# Altium schematic sheet 仪表.SchDoc
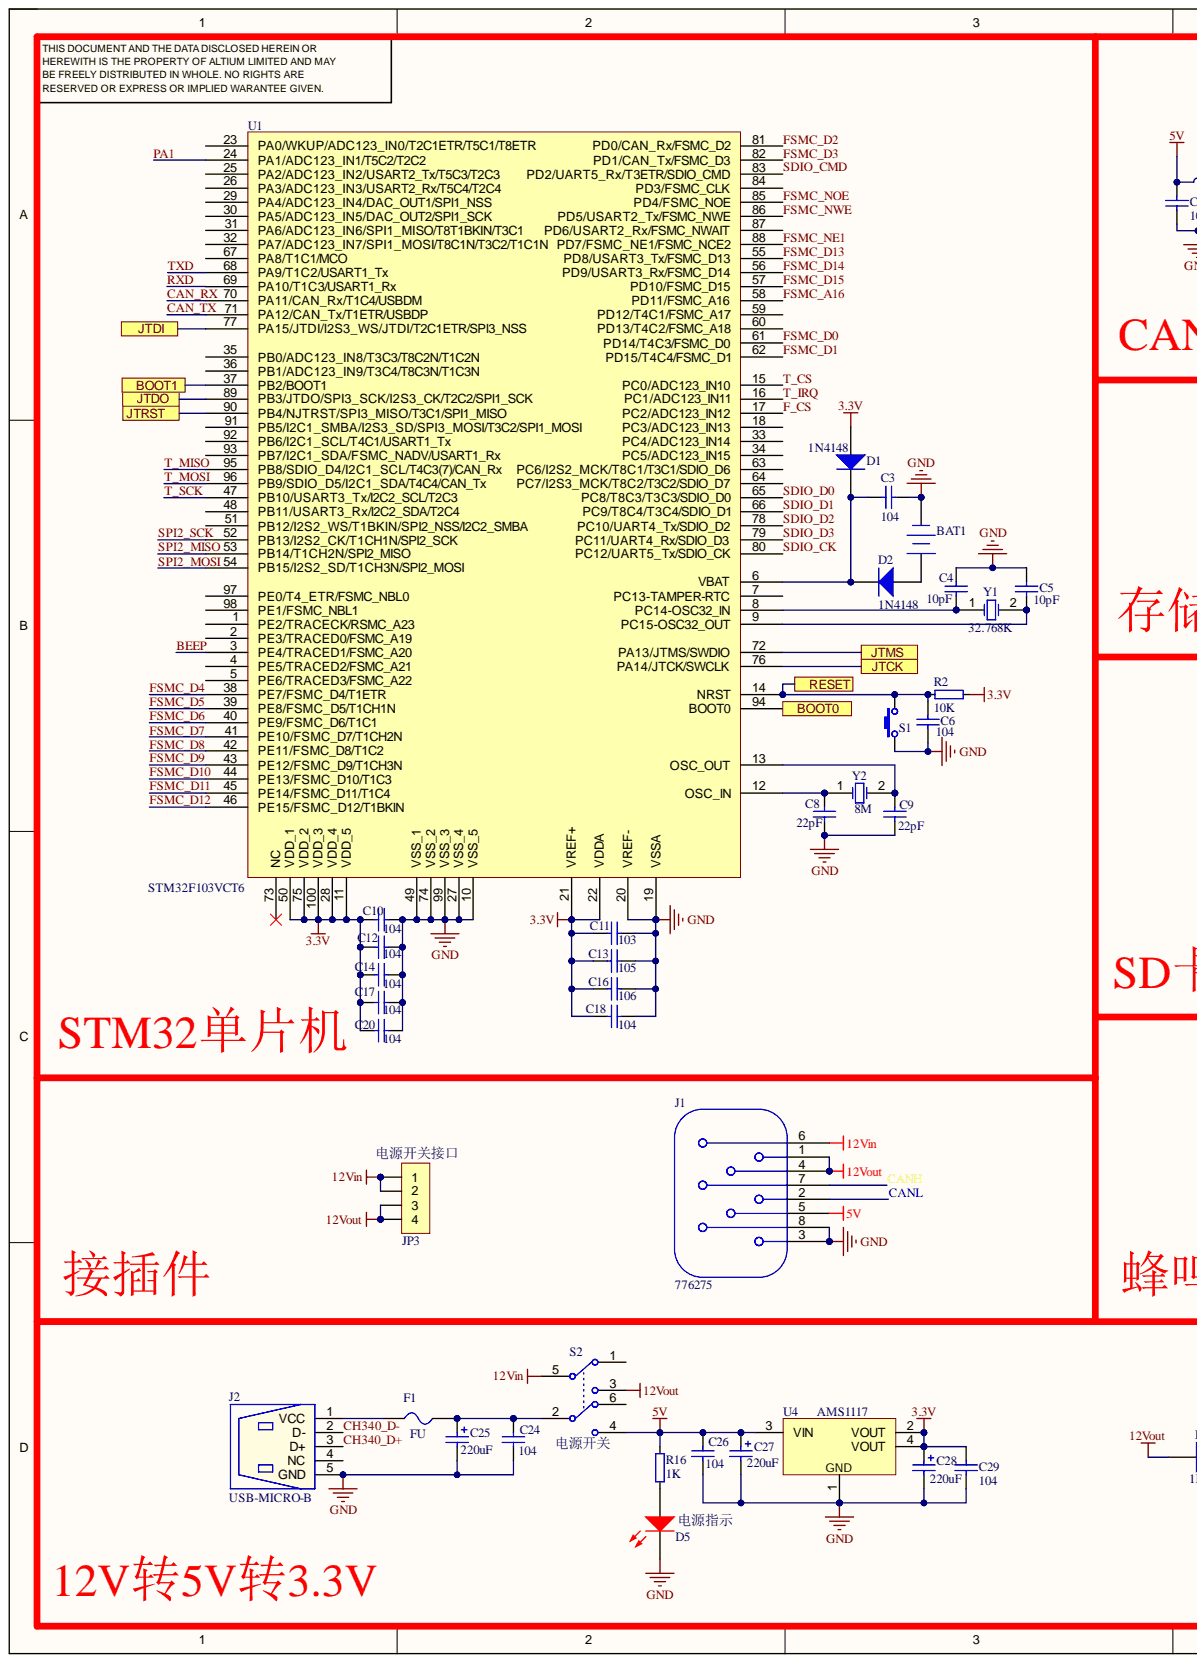
[diagram p0: 仪表.SchDoc, left]
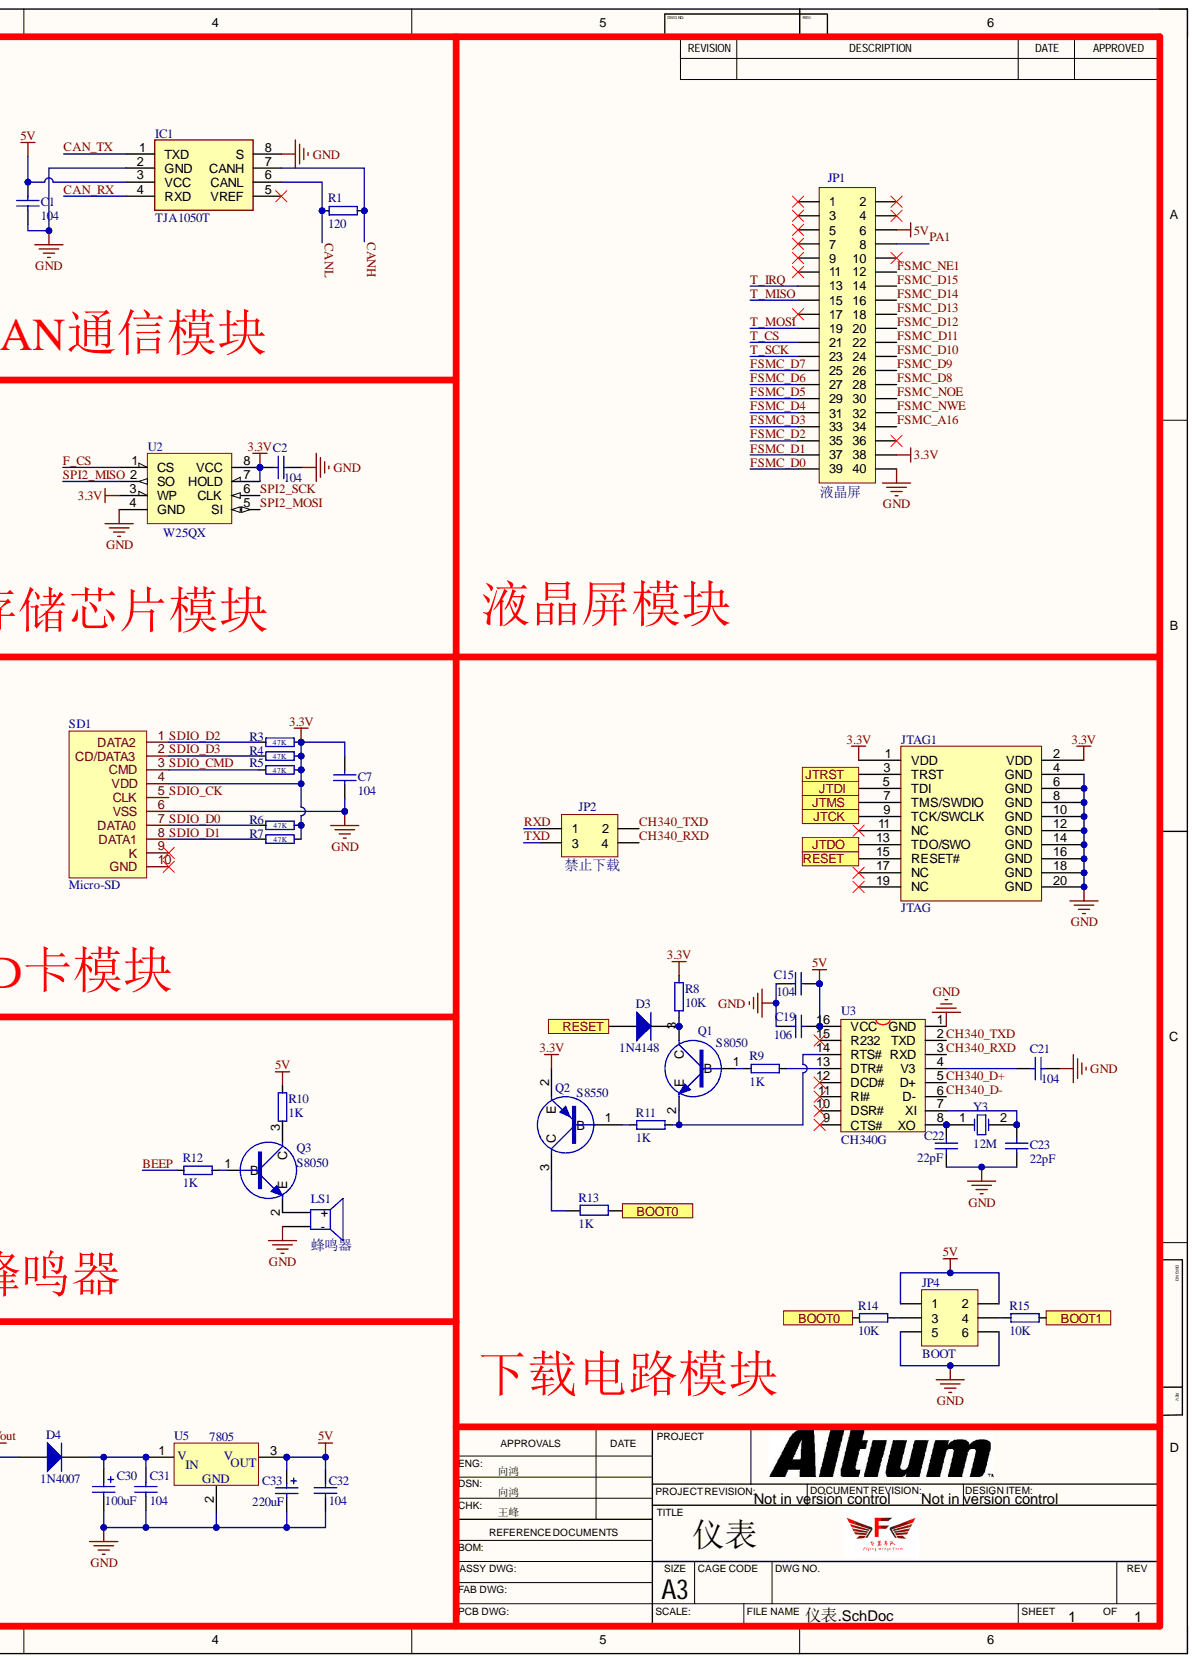
[diagram p1: 仪表.SchDoc, right]

## Parts
BAT1  Battery  [CR1220_B]  (value not drawn)
C1  104  [C0805]
C2  104  [C0805]
C3  104  [C0805]
C4  10pF  [C0805]
C5  10pF  [C0805]
C6  104  [C0805]
C7  104  [C0805]
C8  22pF  [C0805]
C9  22pF  [C0805]
C10  104  [C0805]
C11  103  [C0805]
C12  104  [C0805]
C13  105  [C0805]
C14  104  [C0805]
C15  104  [C0805]
C16  106  [C0805]
C17  104  [C0805]
C18  104  [C0805]
C19  106  [C0805]
C20  104  [C0805]
C21  104  [C0805]
C22  22pF  [C0805]
C23  22pF  [C0805]
C24  104  [C0805]
C25  220uF  [C5X8]
C26  104  [C0805]
C27  220uF  [C5X8]
C28  220uF  [C5X8]
C29  104  [C0805]
C30  100uF  [C5X8]
C31  104  [C0805]
C32  104  [C0805]
C33  220uF  [C5X8]
D1  1N4148  [DO-35]
D2  1N4148  [DO-35]
D3  1N4148  [DO-35]
D4  1N4007  [DO-41]
D5  电源指示  [LED-0805R]
F1  FU  [FU 0805]
IC1  TJA1050T  [TJA1050T]
J1  776275  [776275]
J2  USB-MICRO-B  [USB-Micro-SMT-F4-AB]
JP1  液晶屏  [HDR2.54-M-LS-2x20P]
JP2  禁止下载  [HDR2.54-LI-2x2P]
JP3  电源开关接口  [XH2.54-WI-4P]
JP4  BOOT  [HDR2.54-LI-2x3P]
JTAG1  JTAG  [HDR2.54-LI-2x10P]
LS1  蜂鸣器  [BEEP 7.6x12x9.5]
Q1  S8050  [SOT23-3M]
Q2  S8550  [SOT23-3M]
Q3  S8050  [SOT23-3M]
R1  120  [R0805]
R2  10K  [R0805]
R3  47K  [R0805]
R4  47K  [R0805]
R5  47K  [R0805]
R6  47K  [R0805]
R7  47K  [R0805]
R8  10K  [R0805]
R9  1K  [R0805]
R10  1K  [R0805]
R11  1K  [R0805]
R12  1K  [R0805]
R13  1K  [R0805]
R14  10K  [R0805]
R15  10K  [R0805]
R16  1K  [R0805]
S1  RESET  [SW-6*6*4.3]
S2  电源开关  [KFT DIP-8X8]
SD1  Micro-SD  [Micro SD]
U1  STM32F103VCT6  [LQFP-100_M]
U2  W25QX  [SOP8]
U3  CH340G  [SOP16_M]
U4  AMS1117  [SOT-223]
U5  7805  [TO220]
Y1  32.768K  [OSC-2x6-WI-A]
Y2  8M  [OSC HC-49S]
Y3  12M  [OSC HC-49S]

## Ports
BOOT0, BOOT1, JTCK, JTDI, JTDO, JTMS, JTRST, RESET

## Nets
3.3V: C2.1 C7.2 C10.1 C11.1 C12.1 C13.1 C14.1 C16.1 C17.1 C18.1 C20.1 C28.1 C29.2 D1.1 JP1.38 JTAG1.1(VDD) JTAG1.2(VDD) Q2.2 R2.2 R3.2 R4.2 R5.2 R6.2 R7.2 R8.2 SD1.4(VDD) U1.11(VDD_5) U1.21(VREF+) U1.22(VDDA) U1.28(VDD_4) U1.50(VDD_1) U1.75(VDD_2) U1.100(VDD_3) U2.3(WP) U2.7(HOLD) U2.8(VCC) U4.2(VOUT) U4.4(VOUT)
5V: C1.2 C15.1 C19.1 C26.2 C27.1 C32.2 C33.1 IC1.3(VCC) J1.5 JP1.6 JP4.1 JP4.2 R10.2 R16.2 S2.4 U3.16(VCC) U4.3(VIN) U5.3
12Vin: J1.6 JP3.1 JP3.2 S2.5
12Vout: D4.1 J1.1 J1.4 JP3.3 JP3.4 S2.3
GND: BAT1.2 C1.1 C2.2 C3.1 C4.2 C5.2 C6.1 C7.1 C8.1 C9.1 C10.2 C11.2 C12.2 C13.2 C14.2 C15.2 C16.2 C17.2 C18.2 C19.2 C20.2 C21.1 C22.1 C23.1 C24.1 C25.2 C26.1 C27.2 C28.2 C29.1 C30.2 C31.1 C32.1 C33.2 D5.2 IC1.2(GND) IC1.8(S) J1.3 J1.8 J2.5(GND) JP1.40 JP4.5 JP4.6 JTAG1.4(GND) JTAG1.6(GND) JTAG1.8(GND) JTAG1.10(GND) JTAG1.12(GND) JTAG1.14(GND) JTAG1.16(GND) JTAG1.18(GND) JTAG1.20(GND) LS1.2 S1.1 SD1.6(VSS) U1.10(VSS_5) U1.19(VSSA) U1.20(VREF-) U1.27(VSS_4) U1.49(VSS_1) U1.74(VSS_2) U1.99(VSS_3) U2.4(GND) U3.1(GND) U4.1 U5.2
BEEP: R12.1 U1.3(PE4/TRACED1/FSMC_A20)
BOOT0: R13.1 R14.1 U1.94(BOOT0) ; port BOOT0
BOOT1: R15.2 U1.37(PB2/BOOT1) ; port BOOT1
CAN_RX: IC1.4(RXD) U1.70(PA11/CAN_Rx/T1C4/USBDM)
CAN_TX: IC1.1(TXD) U1.71(PA12/CAN_Tx/T1ETR/USBDP)
CANH: IC1.7(CANH) J1.7 R1.2
CANL: IC1.6(CANL) J1.2 R1.1
CH340_D+: J2.3(D+) U3.5(D+)
CH340_D-: J2.2(D-) U3.6(D-)
CH340_RXD: JP2.4 U3.3(RXD)
CH340_TXD: JP2.2 U3.2(TXD)
F_CS: U1.17(PC2/ADC123_IN12) U2.1(CS)
FSMC_A16: JP1.34 U1.58(PD11/FSMC_A16)
FSMC_D0: JP1.39 U1.61(PD14/T4C3/FSMC_D0)
FSMC_D1: JP1.37 U1.62(PD15/T4C4/FSMC_D1)
FSMC_D2: JP1.35 U1.81(PD0/CAN_Rx/FSMC_D2)
FSMC_D3: JP1.33 U1.82(PD1/CAN_Tx/FSMC_D3)
FSMC_D4: JP1.31 U1.38(PE7/FSMC_D4/T1ETR)
FSMC_D5: JP1.29 U1.39(PE8/FSMC_D5/T1CH1N)
FSMC_D6: JP1.27 U1.40(PE9/FSMC_D6/T1C1)
FSMC_D7: JP1.25 U1.41(PE10/FSMC_D7/T1CH2N)
FSMC_D8: JP1.28 U1.42(PE11/FSMC_D8/T1C2)
FSMC_D9: JP1.26 U1.43(PE12/FSMC_D9/T1CH3N)
FSMC_D10: JP1.24 U1.44(PE13/FSMC_D10/T1C3)
FSMC_D11: JP1.22 U1.45(PE14/FSMC_D11/T1C4)
FSMC_D12: JP1.20 U1.46(PE15/FSMC_D12/T1BKIN)
FSMC_D13: JP1.18 U1.55(PD8/USART3_Tx/FSMC_D13)
FSMC_D14: JP1.16 U1.56(PD9/USART3_Rx/FSMC_D14)
FSMC_D15: JP1.14 U1.57(PD10/FSMC_D15)
FSMC_NE1: JP1.12 U1.88(PD7/FSMC_NE1/FSMC_NCE2)
FSMC_NOE: JP1.30 U1.85(PD4/FSMC_NOE)
FSMC_NWE: JP1.32 U1.86(PD5/USART2_Tx/FSMC_NWE)
JTCK: JTAG1.9(TCK/SWCLK) U1.76(PA14/JTCK/SWCLK) ; port JTCK
JTDI: JTAG1.5(TDI) U1.77(PA15/JTDI/I2S3_WS/JTDI/T2C1ETR/SPI3_NSS) ; port JTDI
JTDO: JTAG1.13(TDO/SWO) U1.89(PB3/JTDO/SPI3_SCK/I2S3_CK/T2C2/SPI1_SCK) ; port JTDO
JTMS: JTAG1.7(TMS/SWDIO) U1.72(PA13/JTMS/SWDIO) ; port JTMS
JTRST: JTAG1.3(TRST) U1.90(PB4/NJTRST/SPI3_MISO/T3C1/SPI1_MISO) ; port JTRST
PA1: JP1.8 U1.24(PA1/ADC123_IN1/T5C2/T2C2)
RESET: C6.2 D3.1 JTAG1.15(RESET#) R2.1 S1.2 U1.14(NRST) ; port RESET
RXD: JP2.1 U1.69(PA10/T1C3/USART1_Rx)
SDIO_CK: SD1.5(CLK) U1.80(PC12/UART5_Tx/SDIO_CK)
SDIO_CMD: R5.1 SD1.3(CMD) U1.83(PD2/UART5_Rx/T3ETR/SDIO_CMD)
SDIO_D0: R6.1 SD1.7(DATA0) U1.65(PC8/T8C3/T3C3/SDIO_D0)
SDIO_D1: R7.1 SD1.8(DATA1) U1.66(PC9/T8C4/T3C4/SDIO_D1)
SDIO_D2: R3.1 SD1.1(DATA2) U1.78(PC10/UART4_Tx/SDIO_D2)
SDIO_D3: R4.1 SD1.2(CD/DATA3) U1.79(PC11/UART4_Rx/SDIO_D3)
SPI2_MISO: U1.53(PB14/T1CH2N/SPI2_MISO) U2.2(SO)
SPI2_MOSI: U1.54(PB15/I2S2_SD/T1CH3N/SPI2_MOSI) U2.5(SI)
SPI2_SCK: U1.52(PB13/I2S2_CK/T1CH1N/SPI2_SCK) U2.6(CLK)
T_CS: JP1.21 U1.15(PC0/ADC123_IN10)
T_IRQ: JP1.13 U1.16(PC1/ADC123_IN11)
T_MISO: JP1.15 U1.95(PB8/SDIO_D4/I2C1_SCL/T4C3(7)/CAN_Rx)
T_MOSI: JP1.19 U1.96(PB9/SDIO_D5/I2C1_SDA/T4C4/CAN_Tx)
T_SCK: JP1.23 U1.47(PB10/USART3_Tx/I2C2_SCL/T2C3)
TXD: JP2.3 U1.68(PA9/T1C2/USART1_Tx)
NetBAT1_1: BAT1.1 D2.1
NetC3_2: C3.2 D1.2 D2.2 U1.6(VBAT)
NetC4_1: C4.1 U1.8(PC14-OSC32_IN) Y1.1
NetC5_1: C5.1 U1.9(PC15-OSC32_OUT) Y1.2
NetC8_2: C8.2 U1.12(OSC_IN) Y2.1
NetC9_2: C9.2 U1.13(OSC_OUT) Y2.2
NetC21_2: C21.2 U3.4(V3)
NetC22_2: C22.2 U3.8(XO) Y3.1
NetC23_2: C23.2 U3.7(XI) Y3.2
NetC24_2: C24.2 C25.1 F1.2 S2.2
NetC30_1: C30.1 C31.2 D4.2 U5.1
NetD3_2: D3.2 Q1.3 R8.1
NetD5_1: D5.1 R16.1
NetF1_1: F1.1 J2.1(VCC)
NetJP4_3: JP4.3 R14.2
NetJP4_4: JP4.4 R15.1
NetLS1_1: LS1.1 Q3.2
NetQ1_1: Q1.1 R9.1
NetQ1_2: Q1.2 R11.2 U3.14(RTS#)
NetQ2_1: Q2.1 R11.1
NetQ2_3: Q2.3 R13.2
NetQ3_1: Q3.1 R12.2
NetQ3_3: Q3.3 R10.1
NetR9_2: R9.2 U3.13(DTR#)

Not connected: IC1 5(VREF); J2 4(NC); JP1 1 2 3 4 5 7 9 10 11 17 36; JTAG1 11(NC) 17(NC) 19(NC); S2 1 6; SD1 9(K) 10(GND); U1 1(PE2/TRACECK/RSMC_A23) 2(PE3/TRACED0/FSMC_A19) 4(PE5/TRACED2/FSMC_A21) 5(PE6/TRACED3/FSMC_A22) 7(PC13-TAMPER-RTC) 18(PC3/ADC123_IN13) 23(PA0/WKUP/ADC123_IN0/T2C1ETR/T5C1/T8ETR) 25(PA2/ADC123_IN2/USART2_Tx/T5C3/T2C3) 26(PA3/ADC123_IN3/USART2_Rx/T5C4/T2C4) 29(PA4/ADC123_IN4/DAC_OUT1/SPI1_NSS) 30(PA5/ADC123_IN5/DAC_OUT2/SPI1_SCK) 31(PA6/ADC123_IN6/SPI1_MISO/T8T1BKIN/T3C1) 32(PA7/ADC123_IN7/SPI1_MOSI/T8C1N/T3C2/T1C1N) 33(PC4/ADC123_IN14) 34(PC5/ADC123_IN15) 35(PB0/ADC123_IN8/T3C3/T8C2N/T1C2N) 36(PB1/ADC123_IN9/T3C4/T8C3N/T1C3N) 48(PB11/USART3_Rx/I2C2_SDA/T2C4) 51(PB12/I2S2_WS/T1BKIN/SPI2_NSS/I2C2_SMBA) 59(PD12/T4C1/FSMC_A17) 60(PD13/T4C2/FSMC_A18) 63(PC6/I2S2_MCK/T8C1/T3C1/SDIO_D6) 64(PC7/I2S3_MCK/T8C2/T3C2/SDIO_D7) 67(PA8/T1C1/MCO) 73(NC) 84(PD3/FSMC_CLK) 87(PD6/USART2_Rx/FSMC_NWAIT) 91(PB5/I2C1_SMBA/I2S3_SD/SPI3_MOSI/T3C2/SPI1_MOSI) 92(PB6/I2C1_SCL/T4C1/USART1_Tx) 93(PB7/I2C1_SDA/FSMC_NADV/USART1_Rx) 97(PE0/T4_ETR/FSMC_NBL0) 98(PE1/FSMC_NBL1); U3 9(CTS#) 10(DSR#) 11(RI#) 12(DCD#) 15(R232)

## Library data of the parts
BAT1 = Battery: Multicell Battery; Component Kind: Standard; LatestRevisionDate: 17-Jul-2002; LatestRevisionNote: Re-released for DXP Platform.; PackageDescription: Battery; 2 Leads; PackageReference: BAT-2; Published: 24-Mar-1999; Library Name: 液晶屏.SchLib; Publisher: Altium Limited
C1-C24 C26 C29 C31 C32 = Cap: Capacitor; Component Kind: Standard; LatestRevisionDate: 17-Jul-2002; LatestRevisionNote: Re-released for DXP Platform.; PackageDescription: Radial Cap, Thru-Hole; 2 Leads; 0.3 in Pin Spacing; PackageReference: RAD-0.3; Published: 8-Jun-2000; Publisher: Altium Limited
  C1: Library Name: BMS系统设计.SchLib
  C2-C24 C26 C29: Library Name: 液晶屏.SchLib
  C31 C32: Library Name: 计时器5.0.SchLib
C25 C27 C28 = Cap Pol: Polarized Capacitor (Radial) 电解电容; Component Kind: Standard; LatestRevisionDate: 17-Jul-2002; LatestRevisionNote: Re-released for DXP Platform.; PackageDescription: Polarized Capacitor (Radial); 2 Leads; PackageReference: RB7.6-15; Published: 8-Jun-2000; Library Name: 液晶屏.SchLib; Publisher: Altium Limited
C30 C33 = Cap Pol: Polarized Capacitor (Radial); Component Kind: Standard; LatestRevisionDate: 17-Jul-2002; LatestRevisionNote: Re-released for DXP Platform.; PackageDescription: Polarized Capacitor (Radial); 2 Leads; PackageReference: RB7.6-15; Published: 8-Jun-2000; Library Name: 计时器5.0.SchLib; Publisher: Altium Limited
D1-D4 = Diode: Default Diode; Code_JEDEC: DO-214-AB; Component Kind: Standard; LatestRevisionDate: 17-Jul-2002; LatestRevisionNote: Re-released for DXP Platform.; PackageDescription: DO-214-AB/SMC; 2 C-Bend Leads; Body 7.9 x 5.9 mm, inc. leads (LxW); PackageReference: SMC; PackageVersion: Sep-1996; Published: 8-Jun-2000; Publisher: Altium Limited
  D4: Library Name: common.SchLib
  D1-D3: Library Name: 液晶屏.SchLib
D5 = LED_red: Typical INFRARED GaAs LED; Component Kind: Standard; LatestRevisionDate: 08-Jul-2005; LatestRevisionNote: Mech Layer 1 removed.; PackageDescription: LED; 2 Leads; PackageReference: LED-0; Published: 8-Jun-2000; Library Name: 液晶屏.SchLib; Publisher: Altium Limited
F1 = FU: 贴片保险丝; 版权归属: 源创客; BOM名称: 贴片保险丝; Component Kind: Standard; 学习资源: www.ooocke.com; Library Name: 液晶屏.SchLib
IC1 = TJA1050T: Integrated Circuit; Manufacturer_Name: Nexperia; Component Kind: Standard; Datasheet Link: http://docs-emea.rs-online.com/webdocs/0425/0900766b80425301.pdf; Height: 1.75mm; Manufacturer_Part_Number: TJA1050T; Mouser Part Number: N/A; Mouser Price/Stock: https://www.mouser.com/Search/Refine.aspx?Keyword=N%2FA; Library Name: BMS系统设计.SchLib
J1 = 776275: 8Pin防水连接器; BOM名称: 776279防水连接器; 创建者: 向鸿; 学习资源: 飞翼车队; Component Kind: Standard; 创建时间: 02-Feb-2021; Library Name: 仪表.SchLib
J2 = USB-MICRO-B-5P: Component Kind: Standard; Library Name: 液晶屏.SchLib
JP1 = Header 20X2: 20*2P接插件; 版权归属: 源创客; BOM名称: 20*2P接插件; Component Kind: Standard; Library Name: 液晶屏.SchLib; 学习资源: www.ooocke.com
JP2 = Header 2X2: 2*2P接插件; 版权归属: 源创客; BOM名称: 2*2P接插件; Component Kind: Standard; 学习资源: www.ooocke.com; Library Name: 液晶屏.SchLib
JP3 = Header 4: 4P接插件; 版权归属: 源创客; BOM名称: 4P接插件; Component Kind: Standard; 学习资源: www.ooocke.com; Library Name: 仪表.SchLib
JP4 = Header 3X2: 3*2P接插件; 版权归属: 源创客; BOM名称: 3*2P接插件; Component Kind: Standard; 学习资源: www.ooocke.com; Library Name: 液晶屏.SchLib
LS1 = 扬声器: Component Kind: Standard; Library Name: motor.SchLib
Q1 Q3 = NPN贴片: 三极管; Component Kind: Standard; Library Name: 液晶屏.SchLib
Q2 = PNP贴片: 三极管; Component Kind: Standard; Library Name: 液晶屏.SchLib
R1-R12 R14-R16 = RES: Component Kind: Standard
  R1: Library Name: BMS系统设计.SchLib
  R2-R12 R14-R16: Library Name: 液晶屏.SchLib
R13 = R: Component Kind: Standard; Library Name: MY_Schlib.SchLib
S1 = SW-PB: Switch; Component Kind: Standard; LatestRevisionDate: 17-Jul-2002; LatestRevisionNote: Re-released for DXP Platform.; PackageDescription: Switch; 2 Leads; PackageReference: SPST-2; Published: 24-Mar-1999; Library Name: 液晶屏.SchLib; Publisher: Altium Limited
S2 = SW-DPDT_306: Double-Pole, Double-Throw Switch; Component Kind: Standard; LatestRevisionDate: 17-Jul-2002; LatestRevisionNote: Re-released for DXP Platform.; PackageDescription: Switch; 6 Leads; PackageReference: DPDT-6; Published: 24-Mar-1999; Library Name: 液晶屏.SchLib; Publisher: Altium Limited
U1 = STM32F103VCTx: 32位STM单片机100引脚; 版权归属: 源创客; BOM名称: 32位STM单片机100引脚; Component Kind: Standard; 学习资源: www.ooocke.com; Library Name: 液晶屏.SchLib
U2 = AD620AN: CI-AD620AN; Component Kind: Standard; Library Name: 液晶屏.SchLib
U5 = 7805: 3-Terminal Positive Voltage Regulator; Component Kind: Standard; Library Name: 计时器5.0.SchLib
Y1-Y3 = XTAL: Crystal Oscillator 晶振; Component Kind: Standard; LatestRevisionDate: 17-Jul-2002; LatestRevisionNote: Re-released for DXP Platform.; PackageDescription: Crystal, Thru-Hole; 2 Leads; Body 3.1 x 8.2 mm (Dia.xH); PackageReference: R38; Published: 8-Jun-2000; Library Name: 液晶屏.SchLib; Publisher: Altium Limited
JTAG1 = JTAG: Component Kind: Standard; Library Name: 液晶屏.SchLib
SD1 = Micro-SD: Component Kind: Standard; Library Name: 液晶屏.SchLib
U3 = CH340G: Component Kind: Standard; Library Name: 液晶屏.SchLib
U4 = AMS1117: Component Kind: Standard; Library Name: 液晶屏.SchLib
